# Altium schematic sheet H2O_Board.SchDoc(H2O_Board)
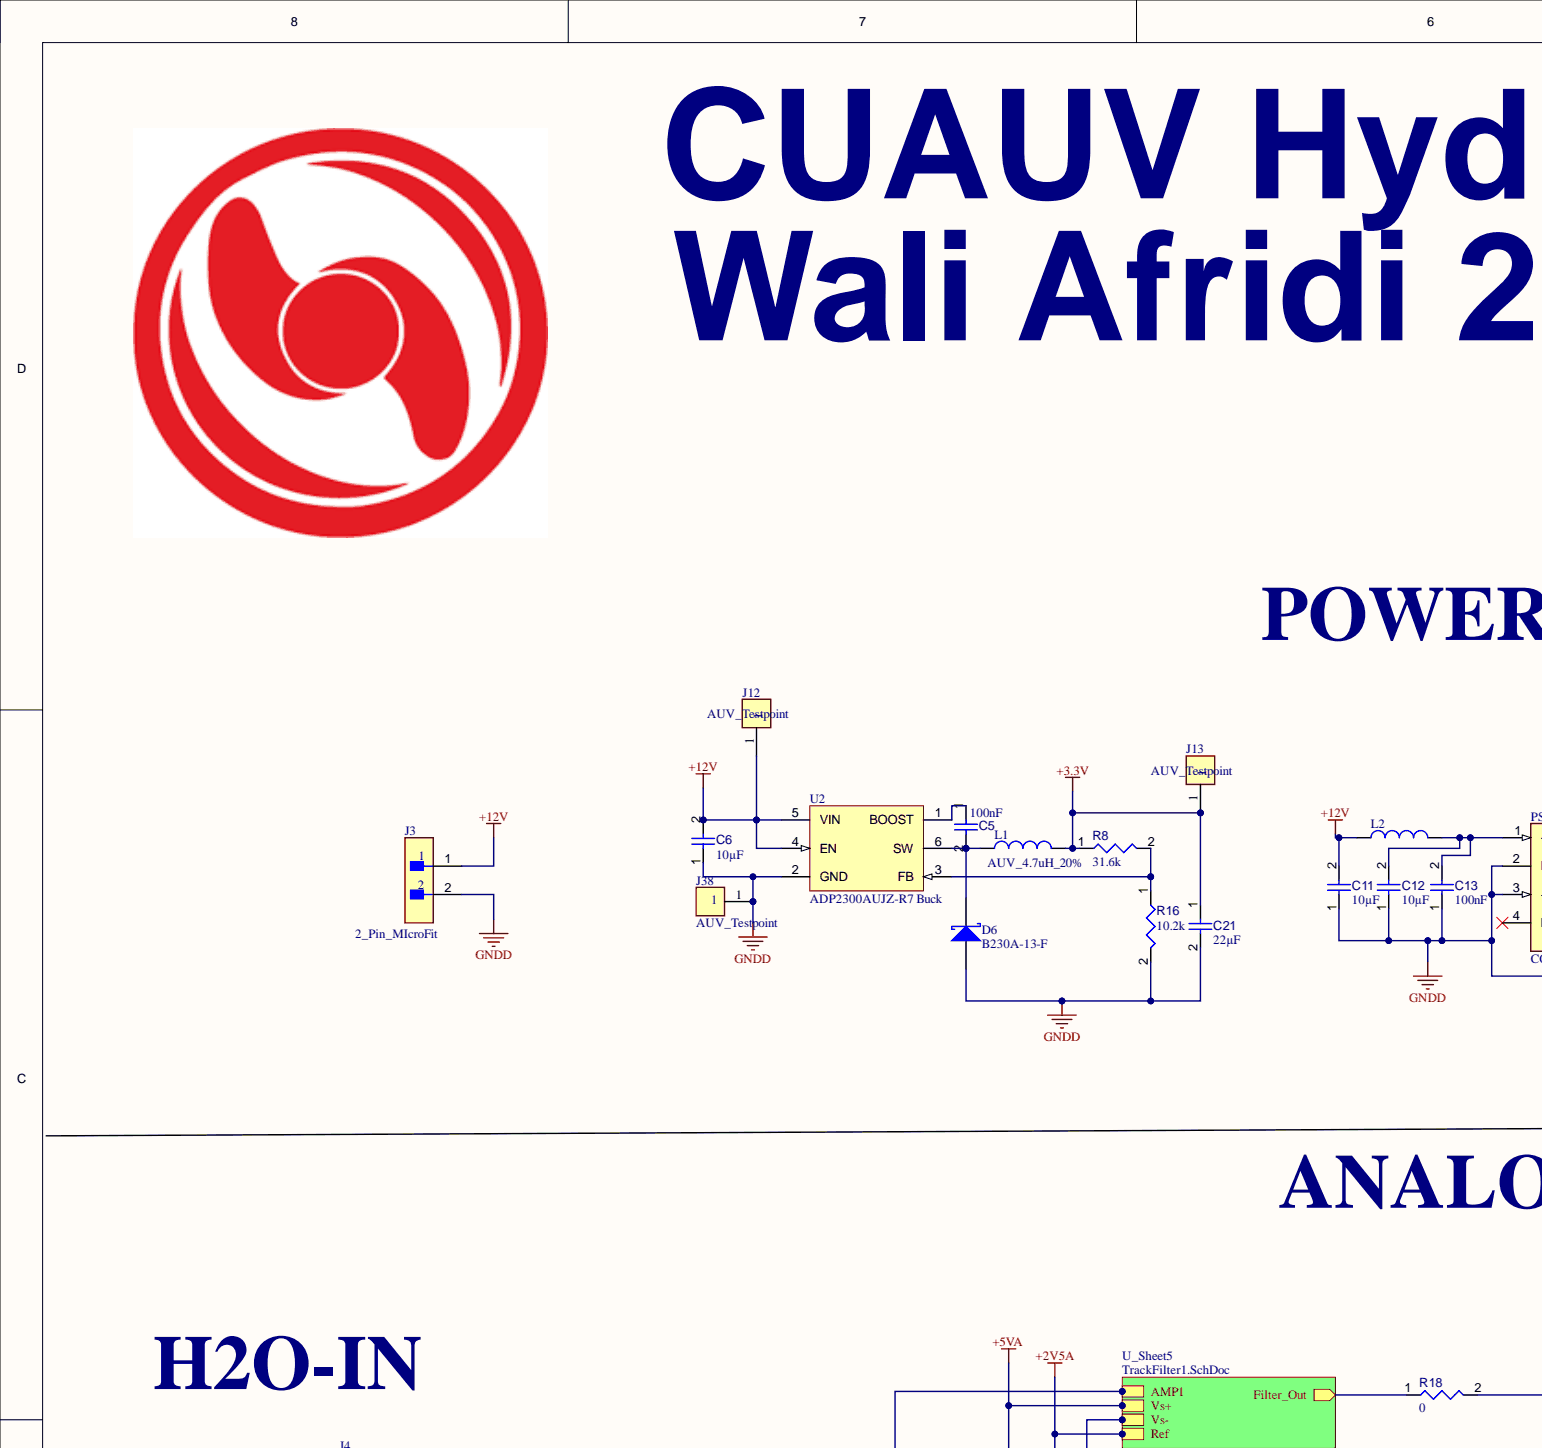
[diagram p0: H2O_Board.SchDoc, top left]
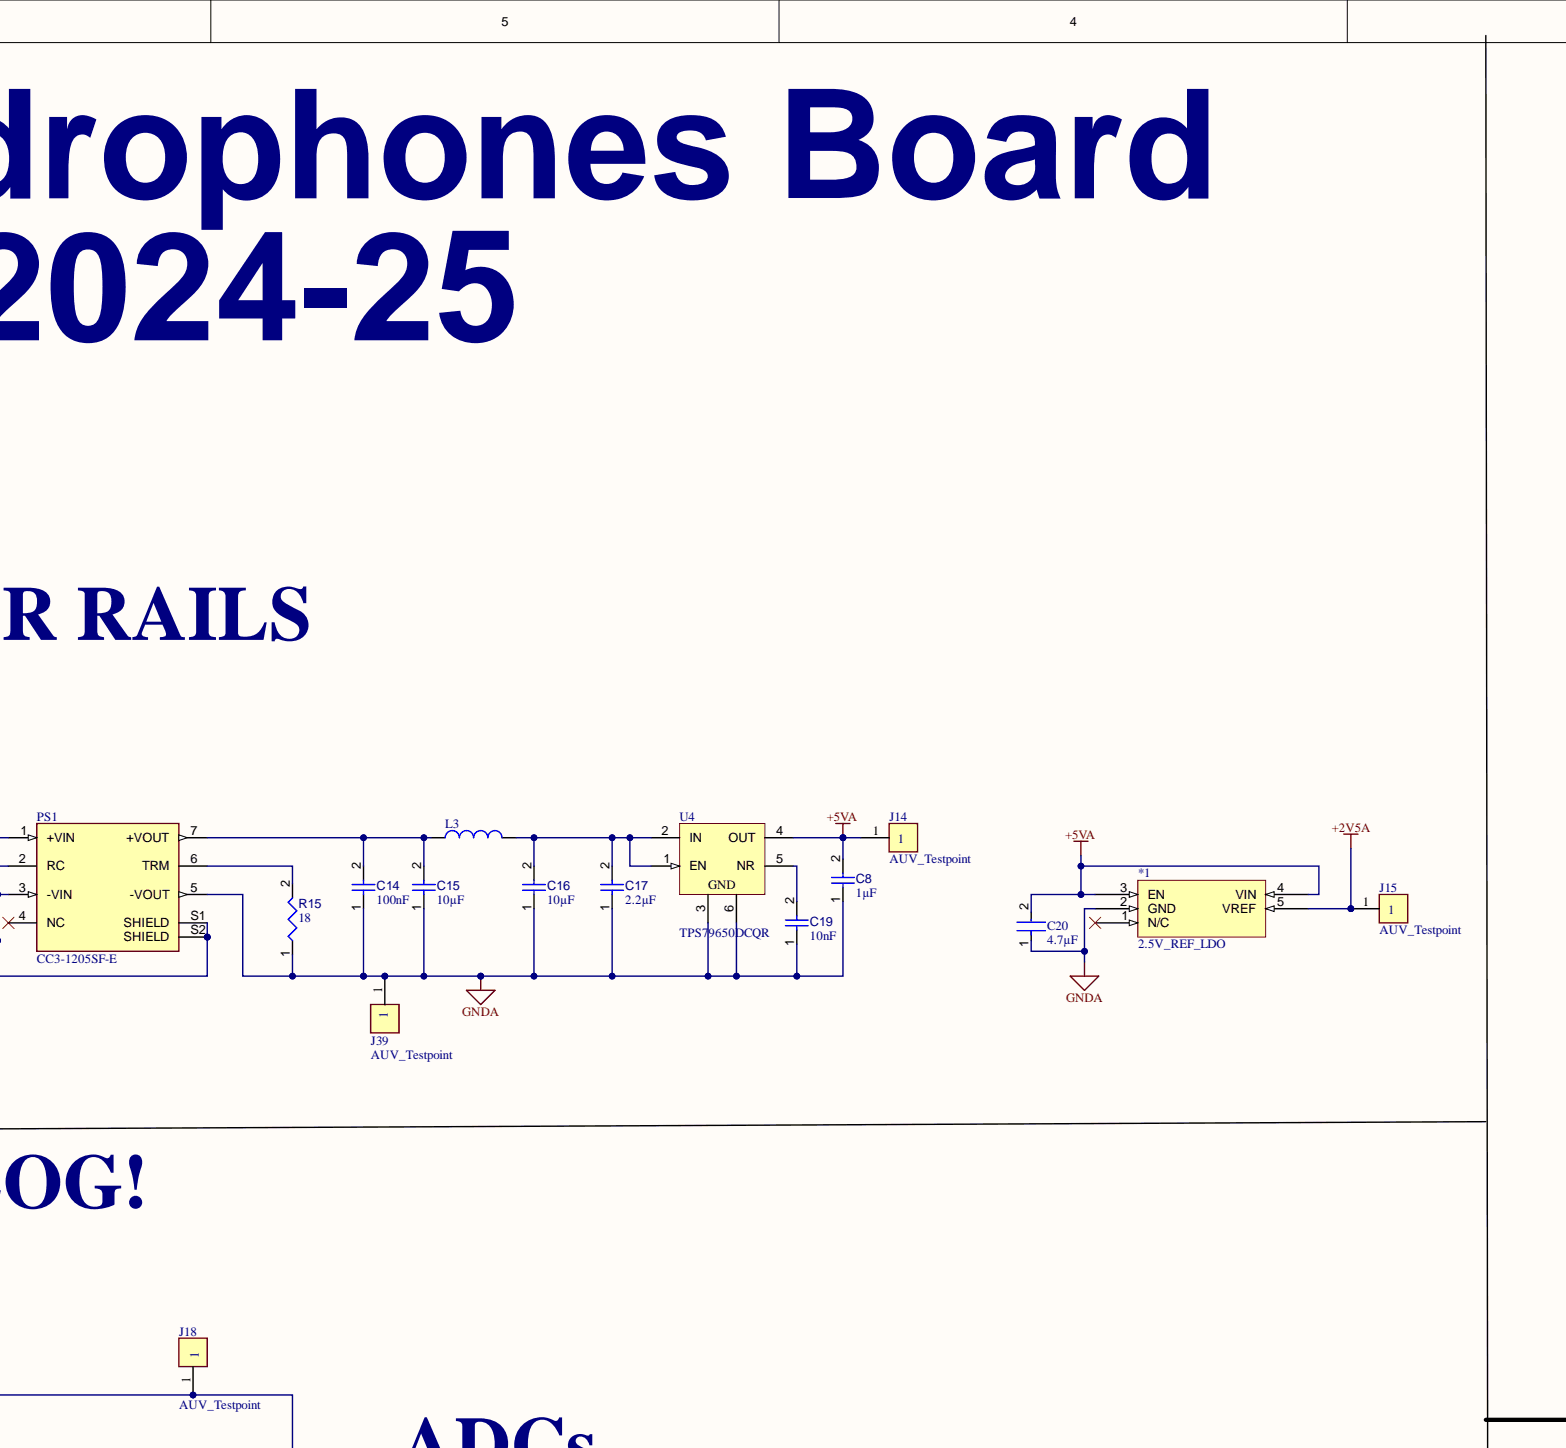
[diagram p1: H2O_Board.SchDoc, top centre]
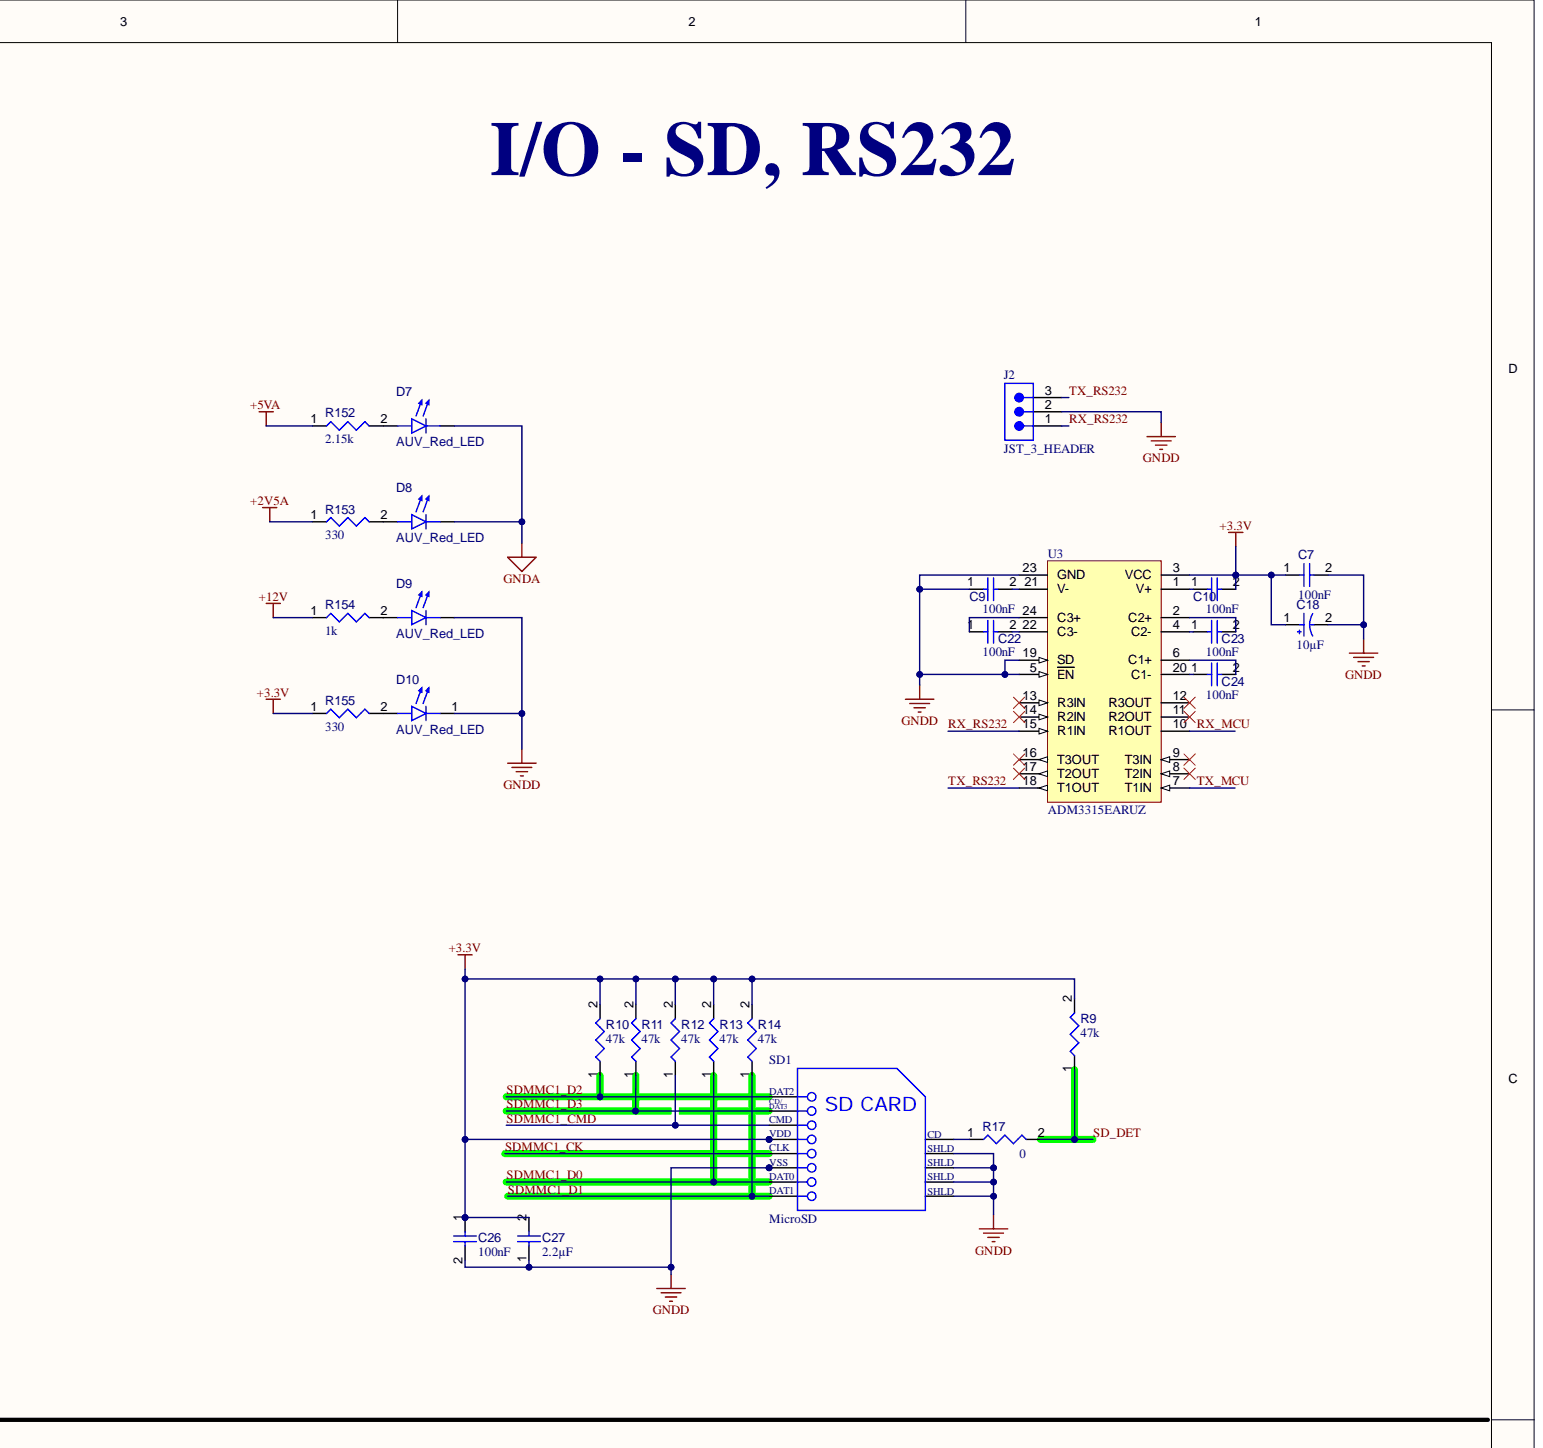
[diagram p2: H2O_Board.SchDoc, top right]
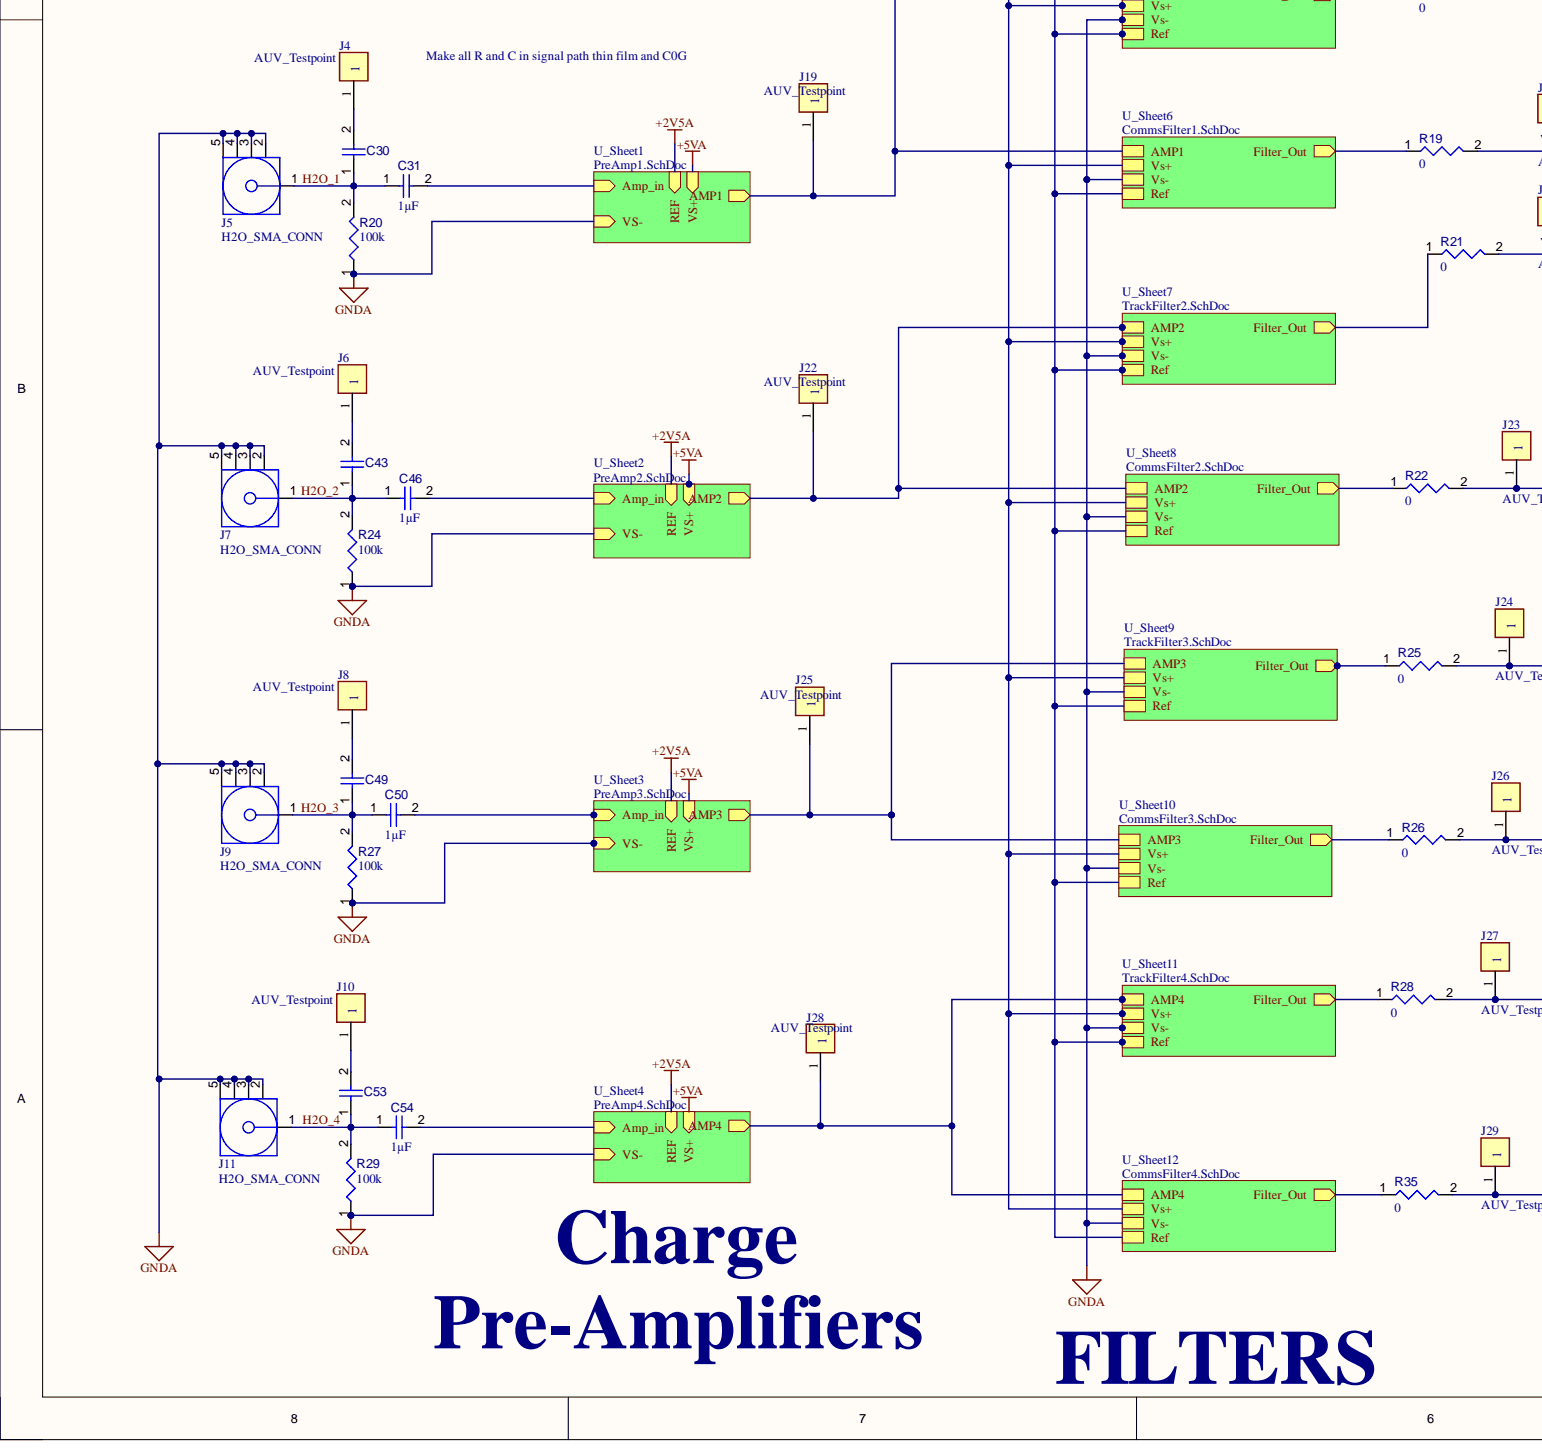
[diagram p3: H2O_Board.SchDoc, bottom left]
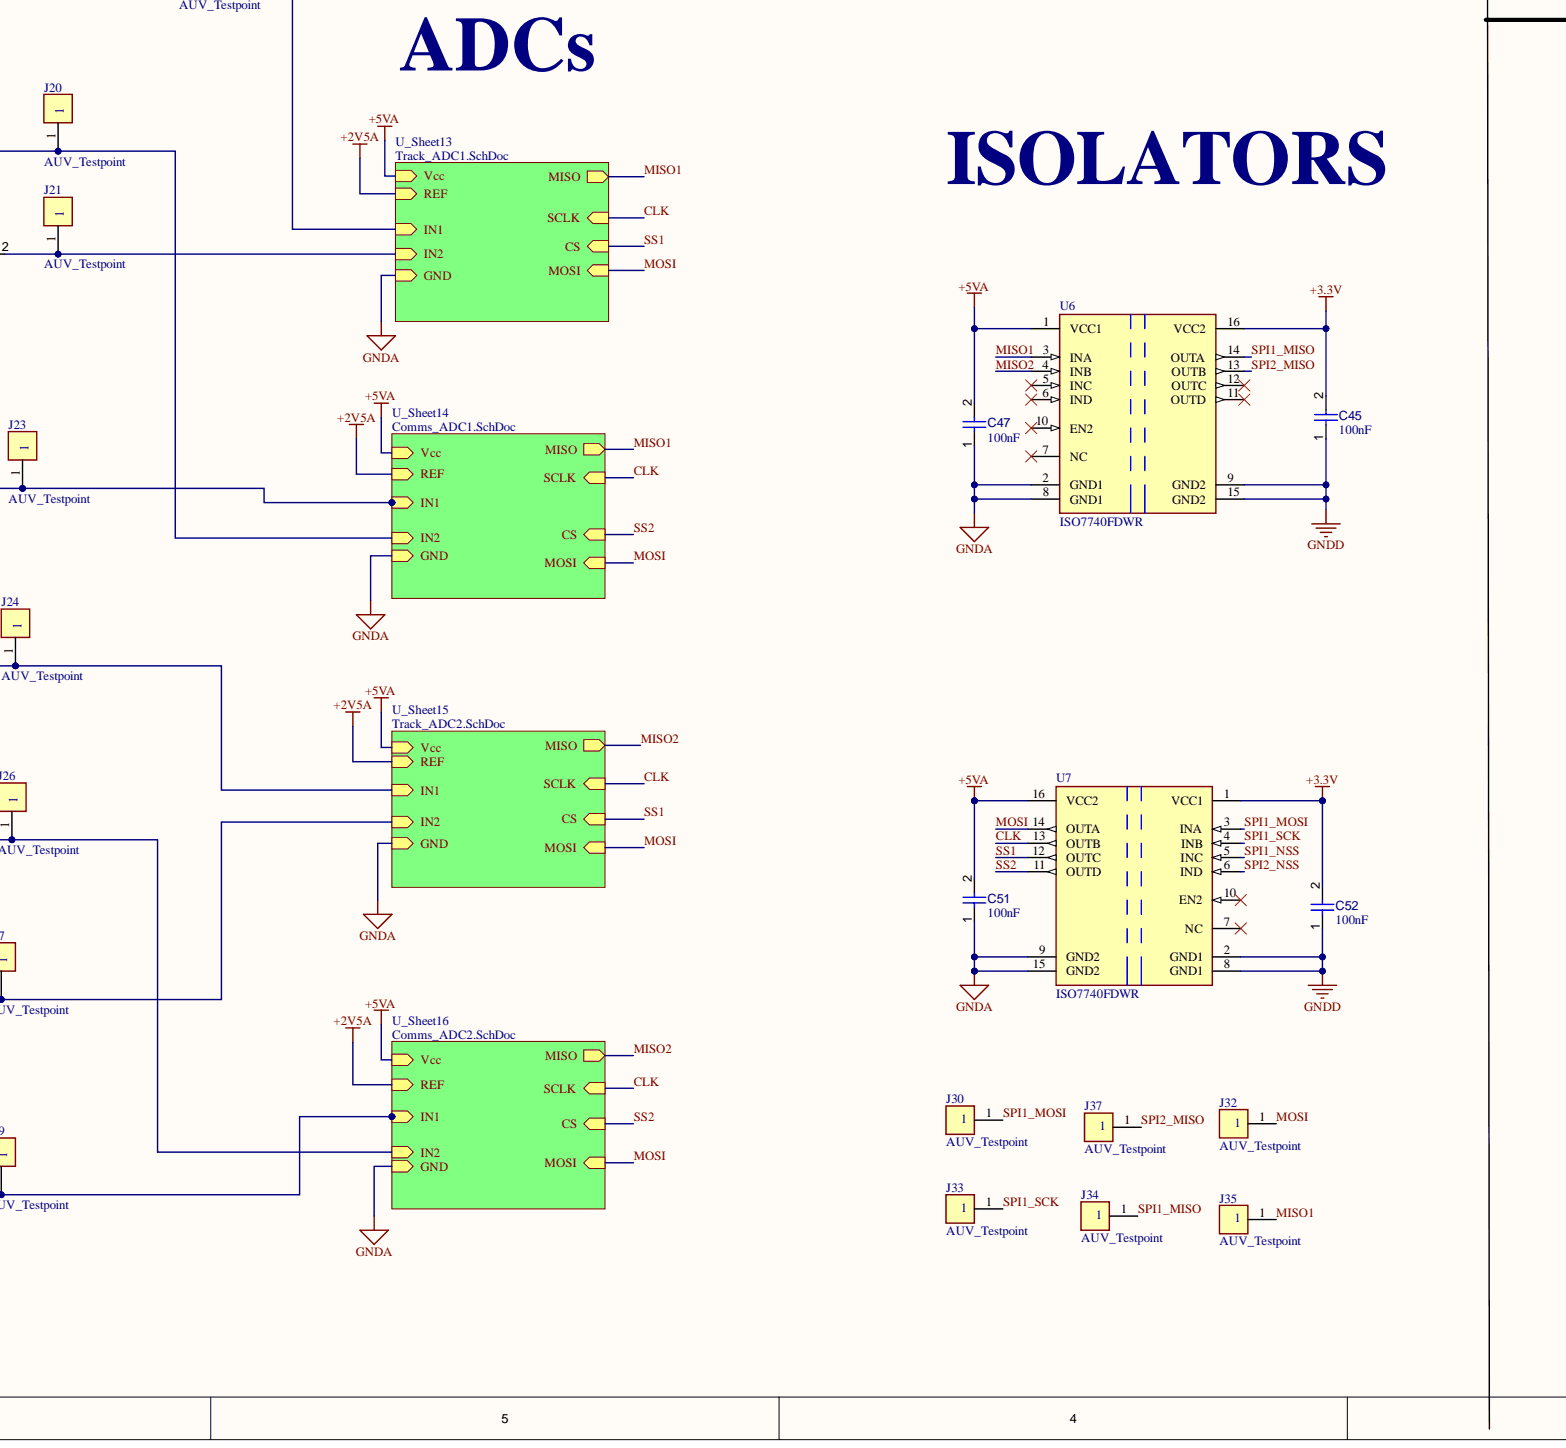
[diagram p4: H2O_Board.SchDoc, bottom centre]
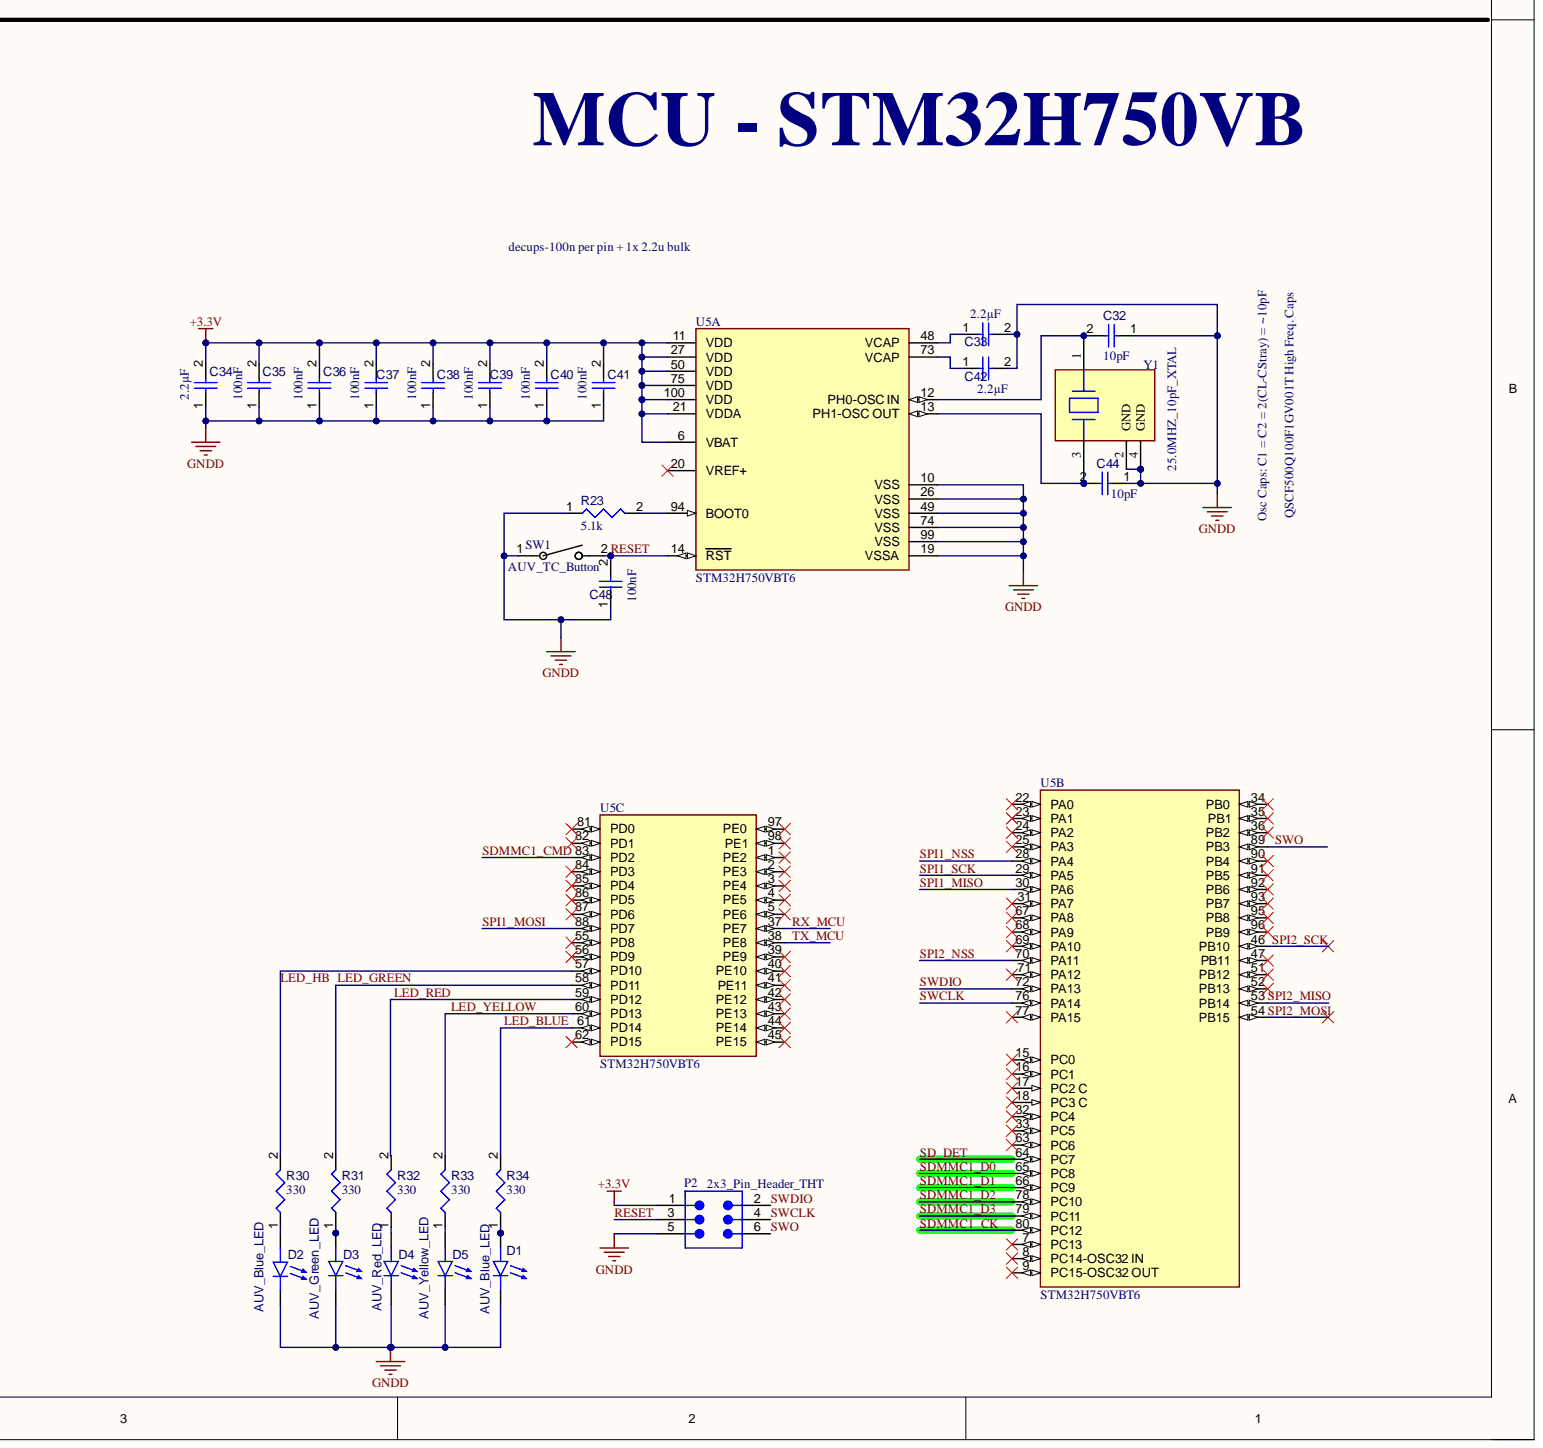
[diagram p5: H2O_Board.SchDoc, bottom right]

## Parts
*1  2.5V_REF_LDO
C5  value 100nF  (hidden comment: AUV_0.1uF_0603)
C6  value 10µF  (hidden comment: AUV_10uF_0603)
C7  value 100nF  (hidden comment: AUV_0.1uF_0603)
C8  value 1µF  (hidden comment: AUV_1uF_0603)
C9  value 100nF  (hidden comment: AUV_0.1uF_0603)
C10  value 100nF  (hidden comment: AUV_0.1uF_0603)
C11  value 10µF  (hidden comment: AUV_10uF_0603)
C12  value 10µF  (hidden comment: AUV_10uF_0603)
C13  value 100nF  (hidden comment: AUV_0.1uF_0603)
C14  value 100nF  (hidden comment: AUV_0.1uF_0603)
C15  value 10µF  (hidden comment: AUV_10uF_0603)
C16  value 10µF  (hidden comment: AUV_10uF_0603)
C17  value 2.2µF  (hidden comment: AUV_2.2uF_0603)
C18  value 10µF  (hidden comment: AUV_10uF_tant_0805)
C19  value 10nF  (hidden comment: AUV_10nF_0603)
C20  value 4.7µF  (hidden comment: AUV_4.7uF_0603)
C21  value 22µF  (hidden comment: AUV_22uF_0603)
C22  value 100nF  (hidden comment: AUV_0.1uF_0603)
C23  value 100nF  (hidden comment: AUV_0.1uF_0603)
C24  value 100nF  (hidden comment: AUV_0.1uF_0603)
C26  value 100nF  (hidden comment: AUV_0.1uF_0603)
C27  value 2.2µF  (hidden comment: AUV_2.2uF_0603)
C30  AUV_300pF_0603  (value not drawn)
C31  value 1µF  (hidden comment: AUV_1uF_0603)
C32  value 10pF  (hidden comment: AUV_10pF_0402)
C33  value 2.2µF  (hidden comment: AUV_2.2uF_0603)
C34  value 2.2µF  (hidden comment: AUV_2.2uF_0603)
C35  value 100nF  (hidden comment: AUV_0.1uF_0603)
C36  value 100nF  (hidden comment: AUV_0.1uF_0603)
C37  value 100nF  (hidden comment: AUV_0.1uF_0603)
C38  value 100nF  (hidden comment: AUV_0.1uF_0603)
C39  value 100nF  (hidden comment: AUV_0.1uF_0603)
C40  value 100nF  (hidden comment: AUV_0.1uF_0603)
C41  AUV_0.1uF_0603  (value not drawn)
C42  value 2.2µF  (hidden comment: AUV_2.2uF_0603)
C43  AUV_300pF_0603  (value not drawn)
C44  value 10pF  (hidden comment: AUV_10pF_0402)
C45  value 100nF  (hidden comment: AUV_0.1uF_0603)
C46  value 1µF  (hidden comment: AUV_1uF_0603)
C47  value 100nF  (hidden comment: AUV_0.1uF_0603)
C48  value 100nF  (hidden comment: AUV_0.1uF_0603)
C49  AUV_300pF_0603  (value not drawn)
C50  value 1µF  (hidden comment: AUV_1uF_0603)
C51  value 100nF  (hidden comment: AUV_0.1uF_0603)
C52  value 100nF  (hidden comment: AUV_0.1uF_0603)
C53  AUV_300pF_0603  (value not drawn)
C54  value 1µF  (hidden comment: AUV_1uF_0603)
D1  AUV_Blue_LED
D2  AUV_Blue_LED
D3  AUV_Green_LED
D4  AUV_Red_LED
D5  AUV_Yellow_LED
D6  B230A-13-F
D7  AUV_Red_LED
D8  AUV_Red_LED
D9  AUV_Red_LED
D10  AUV_Red_LED
J2  JST_3_HEADER
J3  2_Pin_MIcroFit
J4  AUV_Testpoint
J5  H2O_SMA_CONN
J6  AUV_Testpoint
J7  H2O_SMA_CONN
J8  AUV_Testpoint
J9  H2O_SMA_CONN
J10  AUV_Testpoint
J11  H2O_SMA_CONN
J12  AUV_Testpoint
J13  AUV_Testpoint
J14  AUV_Testpoint
J15  AUV_Testpoint
J18  AUV_Testpoint
J19  AUV_Testpoint
J20  AUV_Testpoint
J21  AUV_Testpoint
J22  AUV_Testpoint
J23  AUV_Testpoint
J24  AUV_Testpoint
J25  AUV_Testpoint
J26  AUV_Testpoint
J27  AUV_Testpoint
J28  AUV_Testpoint
J29  AUV_Testpoint
J30  AUV_Testpoint
J32  AUV_Testpoint
J33  AUV_Testpoint
J34  AUV_Testpoint
J35  AUV_Testpoint
J37  AUV_Testpoint
J38  AUV_Testpoint
J39  AUV_Testpoint
L1  AUV_4.7uH_20%
L2  SRN3015-330M  (value not drawn)
L3  SRN3015-330M  (value not drawn)
P2  2x3_Pin_Header_THT
PS1  CC3-1205SF-E
R8  value 31.6k  (hidden comment: AUV_31.6kR_0603)
R9  value 47k  (hidden comment: AUV_47kR_0603)
R10  value 47k  (hidden comment: AUV_47kR_0603)
R11  value 47k  (hidden comment: AUV_47kR_0603)
R12  value 47k  (hidden comment: AUV_47kR_0603)
R13  value 47k  (hidden comment: AUV_47kR_0603)
R14  value 47k  (hidden comment: AUV_47kR_0603)
R15  value 18  (hidden comment: AUV_18R_0603)
R16  value 10.2k  (hidden comment: AUV_10.2kR_0603)
R17  value 0  (hidden comment: AUV_0ohm_0805)
R18  value 0  (hidden comment: AUV_0ohm_0805)
R19  value 0  (hidden comment: AUV_0ohm_0805)
R20  value 100k  (hidden comment: AUV_100kohm_0603)
R21  value 0  (hidden comment: AUV_0ohm_0805)
R22  value 0  (hidden comment: AUV_0ohm_0805)
R23  value 5.1k  (hidden comment: AUV_5.1kR_0603)
R24  value 100k  (hidden comment: AUV_100kohm_0603)
R25  value 0  (hidden comment: AUV_0ohm_0805)
R26  value 0  (hidden comment: AUV_0ohm_0805)
R27  value 100k  (hidden comment: AUV_100kohm_0603)
R28  value 0  (hidden comment: AUV_0ohm_0805)
R29  value 100k  (hidden comment: AUV_100kohm_0603)
R30  value 330  (hidden comment: AUV_330R_0603)
R31  value 330  (hidden comment: AUV_330R_0603)
R32  value 330  (hidden comment: AUV_330R_0603)
R33  value 330  (hidden comment: AUV_330R_0603)
R34  value 330  (hidden comment: AUV_330R_0603)
R35  value 0  (hidden comment: AUV_0ohm_0805)
R152  value 2.15k  (hidden comment: AUV_2.15kR_0603)
R153  value 330  (hidden comment: AUV_330R_0603)
R154  value 1k  (hidden comment: AUV_1kohm_0603)
R155  value 330  (hidden comment: AUV_330R_0603)
SD1  MicroSD
SW1  AUV_TC_Button
U2  ADP2300AUJZ-R7 Buck
U3  ADM3315EARUZ
U4  TPS79650DCQR
U5  STM32H750VBT6  (parts A,B,C)
U6  ISO7740FDWR
U7  ISO7740FDWR
Y1  25.0MHZ_10pF_XTAL

## Nets
+2V5A: *1.5(VREF) J15.1 R153.1
+3.3V: C7.1 C10.2 C18.1 C21.1 C26.1 C27.2 C34.2 C35.2 C36.2 C37.2 C38.2 C39.2 C40.2 C41.2 C45.2 C52.2 J13.1 L1.2 P2.1 R8.1 R9.2 R10.2 R11.2 R12.2 R13.2 R14.2 R155.1 SD1.4 U3.3(VCC) U5.6(VBAT) U5.11(VDD) U5.21(VDDA) U5.27(VDD) U5.50(VDD) U5.75(VDD) U5.100(VDD) U6.16(VCC2) U7.1(VCC1)
+5VA: *1.3(EN) *1.4(VIN) C8.2 C20.2 C47.2 C51.2 J14.1 R152.1 U4.4(OUT) U6.1(VCC1) U7.16(VCC2)
+12V: C6.2 C11.2 J3.1 J12.1 L2.1 R154.1 U2.4(EN) U2.5(VIN)
GNDA: *1.2(GND) C8.1 C14.1 C15.1 C16.1 C17.1 C19.1 C20.1 C47.1 C51.1 D7.1 D8.1 J5.2 J5.3 J5.4 J5.5 J7.2 J7.3 J7.4 J7.5 J9.2 J9.3 J9.4 J9.5 J11.2 J11.3 J11.4 J11.5 J39.1 PS1.5(-VOUT) R15.1 R20.1 R24.1 R27.1 R29.1 U4.3 U4.6 U6.2(GND1) U6.8(GND1) U7.9(GND2) U7.15(GND2)
GNDD: C6.1 C7.2 C9.1 C11.1 C12.1 C13.1 C18.2 C21.2 C26.2 C27.1 C32.1 C33.2 C34.1 C35.1 C36.1 C37.1 C38.1 C39.1 C40.1 C41.1 C42.2 C44.1 C45.1 C48.1 C52.1 D1.1 D2.1 D3.1 D4.1 D5.1 D6.1 D9.1 D10.1 J2.2 J3.2 J38.1 P2.5 PS1.2(RC) PS1.3(-VIN) PS1.S1(SHIELD) PS1.S2(SHIELD) R16.2 R23.1 SD1.6 SD1.10 SD1.11 SD1.12 SD1.13 SW1.1 U2.2(GND) U3.5(EN) U3.19(SD) U3.23(GND) U5.10(VSS) U5.19(VSSA) U5.26(VSS) U5.49(VSS) U5.74(VSS) U5.99(VSS) U6.9(GND2) U6.15(GND2) U7.2(GND1) U7.8(GND1) Y1.2 Y1.4
CLK: U7.13(OUTB)
H2O_1: C30.1 C31.1 J5.1 R20.2
H2O_2: C43.1 C46.1 J7.1 R24.2
H2O_3: C49.1 C50.1 J9.1 R27.2
H2O_4: C53.1 C54.1 J11.1 R29.2
LED_BLUE: R34.2 U5.61(PD14)
LED_GREEN: R31.2 U5.58(PD11)
LED_HB: R30.2 U5.57(PD10)
LED_RED: R32.2 U5.59(PD12)
LED_YELLOW: R33.2 U5.60(PD13)
MISO1: J35.1 U6.3(INA)
MISO2: U6.4(INB)
MOSI: J32.1 U7.14(OUTA)
RESET: C48.2 P2.3 SW1.2 U5.14(RST)
RX_MCU: U3.10(R1OUT) U5.37(PE7)
RX_RS232: J2.1 U3.15(R1IN)
SD_DET: R9.1 R17.2 U5.64(PC7)
SDMMC1_CK: SD1.5 U5.80(PC12)
SDMMC1_CMD: R12.1 SD1.3 U5.83(PD2)
SDMMC1_D0: R13.1 SD1.7 U5.65(PC8)
SDMMC1_D1: R14.1 SD1.8 U5.66(PC9)
SDMMC1_D2: R10.1 SD1.1(SD_CARD) U5.78(PC10)
SDMMC1_D3: R11.1 SD1.2 U5.79(PC11)
SPI1_MISO: J34.1 U5.30(PA6) U6.14(OUTA)
SPI1_MOSI: J30.1 U5.88(PD7) U7.3(INA)
SPI1_NSS: U5.28(PA4) U7.5(INC)
SPI1_SCK: J33.1 U5.29(PA5) U7.4(INB)
SPI2_MISO: J37.1 U5.53(PB14) U6.13(OUTB)
SPI2_MOSI: U5.54(PB15)
SPI2_NSS: U5.70(PA11) U7.6(IND)
SPI2_SCK: U5.46(PB10)
SS1: U7.12(OUTC)
SS2: U7.11(OUTD)
SWCLK: P2.4 U5.76(PA14)
SWDIO: P2.2 U5.72(PA13)
SWO: P2.6 U5.89(PB3)
TX_MCU: U3.7(T1IN) U5.38(PE8)
TX_RS232: J2.3 U3.18(T1OUT)
Net*1_1: *1.1(N/C)
NetC5_1: C5.1 U2.1(BOOST)
NetC5_2: C5.2 D6.2 L1.1 U2.6(SW)
NetC9_2: C9.2 U3.21(V-)
NetC10_1: C10.1 U3.1(V+)
NetC12_2: C12.2 C13.2 L2.2 PS1.1(+VIN)
NetC14_2: C14.2 C15.2 L3.1 PS1.7(+VOUT)
NetC16_2: C16.2 C17.2 L3.2 U4.1(EN) U4.2(IN)
NetC19_2: C19.2 U4.5(NR)
NetC22_1: C22.1 U3.24(C3+)
NetC22_2: C22.2 U3.22(C3-)
NetC23_1: C23.1 U3.4(C2-)
NetC23_2: C23.2 U3.2(C2+)
NetC24_1: C24.1 U3.20(C1-)
NetC24_2: C24.2 U3.6(C1+)
NetC30_2: C30.2 J4.1
NetC31_2: C31.2
NetC32_2: C32.2 U5.12(PH0-OSC_IN) Y1.1
NetC33_1: C33.1 U5.48(VCAP)
NetC42_1: C42.1 U5.73(VCAP)
NetC43_2: C43.2 J6.1
NetC44_2: C44.2 U5.13(PH1-OSC_OUT) Y1.3
NetC46_2: C46.2
NetC49_2: C49.2 J8.1
NetC50_2: C50.2
NetC53_2: C53.2 J10.1
NetC54_2: C54.2
NetD1_2: D1.2 R34.1
NetD2_2: D2.2 R30.1
NetD3_2: D3.2 R31.1
NetD4_2: D4.2 R32.1
NetD5_2: D5.2 R33.1
NetD7_2: D7.2 R152.2
NetD8_2: D8.2 R153.2
NetD9_2: D9.2 R154.2
NetD10_2: D10.2 R155.2
NetJ18_1: J18.1 R18.2
NetJ19_1: J19.1
NetJ20_1: J20.1 R19.2
NetJ21_1: J21.1 R21.2
NetJ22_1: J22.1
NetJ23_1: J23.1 R22.2
NetJ24_1: J24.1 R25.2
NetJ25_1: J25.1
NetJ26_1: J26.1 R26.2
NetJ27_1: J27.1 R28.2
NetJ28_1: J28.1
NetJ29_1: J29.1 R35.2
NetPS1_4: PS1.4(NC)
NetPS1_6: PS1.6(TRM) R15.2
NetR8_2: R8.2 R16.1 U2.3(FB)
NetR17_1: R17.1 SD1.9
NetR18_1: R18.1
NetR19_1: R19.1
NetR21_1: R21.1
NetR22_1: R22.1
NetR23_2: R23.2 U5.94(BOOT0)
NetR25_1: R25.1
NetR26_1: R26.1
NetR28_1: R28.1
NetR35_1: R35.1
NetU3_8: U3.8(T2IN)
NetU3_9: U3.9(T3IN)
NetU3_11: U3.11(R2OUT)
NetU3_12: U3.12(R3OUT)
NetU3_13: U3.13(R3IN)
NetU3_14: U3.14(R2IN)
NetU3_16: U3.16(T3OUT)
NetU3_17: U3.17(T2OUT)
NetU5_1: U5.1(PE2)
NetU5_2: U5.2(PE3)
NetU5_3: U5.3(PE4)
NetU5_4: U5.4(PE5)
NetU5_5: U5.5(PE6)
NetU5_7: U5.7(PC13)
NetU5_8: U5.8(PC14-OSC32_IN)
NetU5_9: U5.9(PC15-OSC32_OUT)
NetU5_15: U5.15(PC0)
NetU5_16: U5.16(PC1)
NetU5_17: U5.17(PC2_C)
NetU5_18: U5.18(PC3_C)
NetU5_20: U5.20(VREF+)
NetU5_22: U5.22(PA0)
NetU5_23: U5.23(PA1)
NetU5_24: U5.24(PA2)
NetU5_25: U5.25(PA3)
NetU5_31: U5.31(PA7)
NetU5_32: U5.32(PC4)
NetU5_33: U5.33(PC5)
NetU5_34: U5.34(PB0)
NetU5_35: U5.35(PB1)
NetU5_36: U5.36(PB2)
NetU5_39: U5.39(PE9)
NetU5_40: U5.40(PE10)
NetU5_41: U5.41(PE11)
NetU5_42: U5.42(PE12)
NetU5_43: U5.43(PE13)
NetU5_44: U5.44(PE14)
NetU5_45: U5.45(PE15)
NetU5_47: U5.47(PB11)
NetU5_51: U5.51(PB12)
NetU5_52: U5.52(PB13)
NetU5_55: U5.55(PD8)
NetU5_56: U5.56(PD9)
NetU5_62: U5.62(PD15)
NetU5_63: U5.63(PC6)
NetU5_67: U5.67(PA8)
NetU5_68: U5.68(PA9)
NetU5_69: U5.69(PA10)
NetU5_71: U5.71(PA12)
NetU5_77: U5.77(PA15)
NetU5_81: U5.81(PD0)
NetU5_82: U5.82(PD1)
NetU5_84: U5.84(PD3)
NetU5_85: U5.85(PD4)
NetU5_86: U5.86(PD5)
NetU5_87: U5.87(PD6)
NetU5_90: U5.90(PB4)
NetU5_91: U5.91(PB5)
NetU5_92: U5.92(PB6)
NetU5_93: U5.93(PB7)
NetU5_95: U5.95(PB8)
NetU5_96: U5.96(PB9)
NetU5_97: U5.97(PE0)
NetU5_98: U5.98(PE1)
NetU6_5: U6.5(INC)
NetU6_6: U6.6(IND)
NetU6_7: U6.7(NC)
NetU6_10: U6.10(EN2)
NetU6_11: U6.11(OUTD)
NetU6_12: U6.12(OUTC)
NetU7_7: U7.7(NC)
NetU7_10: U7.10(EN2)
